# Altium schematic sheet Bitcoiner_EB_Project_V1I1_Schematic.SchDoc (continued)

## Library data of the parts
C1 C3 C12 C13 C33 = T491A475K016AT: Tantalum capacitor 4.7 uF 16 VDC, T491A475K016AT, KEMET; Capacitance: 4.7uF; Dissipation Factor: 6%; ESR (Equivalent Series Resistance): 4R; Leakage Current: 800nA; Life (Hours): 2000Hour; Polarity: Polar; Ripple Current: 137mA; Tolerance: 10%; Case Code (Imperial): 1206; Case Code (Metric): 3216; Case/Package: 1206; Category: Tantalum Capacitors; Component Kind: Standard; ComponentLink1Description: https://datasheet.ciiva.com/34302/1573425-34302513.pdf?src-supplier=Jameco; ComponentLink1URL: https://datasheet.ciiva.com/34302/1573425-34302513.pdf?src-supplier=Jameco; ComponentLink2Description: https://datasheet.ciiva.com/11793/0900766b80718482-11793043.pdf?src-supplier=RSComponents; ComponentLink2URL: https://datasheet.ciiva.com/11793/0900766b80718482-11793043.pdf?src-supplier=RSComponents; ComponentLink3Description: https://datasheet.ciiva.com/20171/458253-da-en-01-20171911.pdf?src-supplier=Rapid+Electronics; ComponentLink3URL: https://datasheet.ciiva.com/20171/458253-da-en-01-20171911.pdf?src-supplier=Rapid+Electronics; ComponentLink4Description: https://datasheet.ciiva.com/257/kem-t2005-t491-257536.pdf?src-supplier=Digi-Key; ComponentLink4URL: https://datasheet.ciiva.com/257/kem-t2005-t491-257536.pdf?src-supplier=Digi-Key; ComponentLink5Description: https://datasheet.ciiva.com/257/kem-t2005-t491-257537.pdf?src-supplier=Digi-Key; ComponentLink5URL: https://datasheet.ciiva.com/257/kem-t2005-t491-257537.pdf?src-supplier=Digi-Key; ComponentLink6Description: https://datasheet.ciiva.com/257/kem-t2005-t491-257538.pdf?src-supplier=Digi-Key; ComponentLink6URL: https://datasheet.ciiva.com/257/kem-t2005-t491-257538.pdf?src-supplier=Digi-Key; ComponentLink7Description: https://datasheet.ciiva.com/7696/1854511-7696309.pdf?src-supplier=Element14; ComponentLink7URL: https://datasheet.ciiva.com/7696/1854511-7696309.pdf?src-supplier=Element14; ComponentLink8Description: https://datasheet.ciiva.com/14605/70095774-14605189.pdf?src-supplier=Allied+Electronics; ComponentLink8URL: https://datasheet.ciiva.com/14605/70095774-14605189.pdf?src-supplier=Allied+Electronics; ComponentLink9Description: https://datasheet.ciiva.com/7696/1854511-7696310.pdf?src-supplier=Element14; ComponentLink9URL: https://datasheet.ciiva.com/7696/1854511-7696310.pdf?src-supplier=Element14; ComponentLink10Description: https://datasheet.ciiva.com/7696/1854511-7696311.pdf?src-supplier=Element14; ComponentLink10URL: https://datasheet.ciiva.com/7696/1854511-7696311.pdf?src-supplier=Element14; ComponentLink11Description: https://datasheet.ciiva.com/7696/1854511-7696312.pdf?src-supplier=Element14; ComponentLink11URL: https://datasheet.ciiva.com/7696/1854511-7696312.pdf?src-supplier=Element14; ComponentLink12Description: https://datasheet.ciiva.com/12883/kem-t2005-t491-12883130.pdf?src-supplier=Mouser; ComponentLink12URL: https://datasheet.ciiva.com/12883/kem-t2005-t491-12883130.pdf?src-supplier=Mouser; ComponentLink13Description: https://datasheet.ciiva.com/14603/70095774-14603739.pdf?src-supplier=Allied+Electronics; ComponentLink13URL: https://datasheet.ciiva.com/14603/70095774-14603739.pdf?src-supplier=Allied+Electronics; ComponentLink14Description: https://datasheet.ciiva.com/5934/kem-t2005-t491-5934799.pdf?src-supplier=Farnell; ComponentLink14URL: https://datasheet.ciiva.com/5934/kem-t2005-t491-5934799.pdf?src-supplier=Farnell; ComponentLink15Description: https://datasheet.ciiva.com/29244/458253-da-01-en-tantal-kondensator-t491a475k016at-29244572.pdf?src-supplier=Conrad; ComponentLink15URL: https://datasheet.ciiva.com/29244/458253-da-01-en-tantal-kondensator-t491a475k016at-29244572.pdf?src-supplier=Conrad; Construction: Flat; Depth: 1.6mm; Dielectric Material: Tantalum; Features: General Purpose; Height: 1.6mm; Height - Seated (Max): 0.071inch; Lead Free: Lead Free; Length: 3.2mm; Manufacturer: KEMET; Manufacturer Part Number: T491A475K016AT; Max Operating Temperature: 125°C; Min Operating Temperature: -55°C; Mount: Surface Mount,PCB; Number of Pins: 2; Package Quantity: 1; Packaging: Tape and Reel; Pricing 1: 1=0.43000, 10=0.24500, 50=0.24500, 100=0.14900, 1000=0.12200, 10000=0.10600 (USD); Radiation Hardening: No; REACH SVHC: No SVHC; RoHS: Yes; RoHS Compliant: Yes; Series: T491; Stock 1: 9766; Supplier 1: Mouser; Supplier Part Number 1: 80-T491A475K016; Termination: SMD/SMT; Voltage Rating: 16V; Voltage Rating (DC): 16V; Width: 1.6mm; Library Name: Bitcoiner_EB_Project_V1I1.SchLib
  C1 C3 C12 C13: Voltage: 16V
C2 C4-C6 C9-C11 C16 C18-C28 C30 = 08055C104KAT4A: AVX - 08055C104KAT4A - Multilayer Ceramic Capacitor, 0.1 F, 10%, X7R, 50 V, 0805 [2012 Metric] RoHS Compliant: Yes; Manufacturer: Kyocera AVX; Capacitance: 100nF; Dissipation Factor: 2.5%; Voltage: 50V; Case Code (Imperial): 0805; Case Code (Metric): 2012; Case/Package: 0805; Category: Ceramic Capacitors; Component Kind: Standard; ComponentLink1Description: https://datasheet.ciiva.com/2988/cx7r-2988016.pdf?src-supplier=Avnet; ComponentLink1URL: https://datasheet.ciiva.com/2988/cx7r-2988016.pdf?src-supplier=Avnet; ComponentLink2Description: https://datasheet.ciiva.com/28613/1679506-28613114.pdf?src-supplier=Element14; ComponentLink2URL: https://datasheet.ciiva.com/28613/1679506-28613114.pdf?src-supplier=Element14; ComponentLink3Description: https://datasheet.ciiva.com/5423/cx7r-218113-5423437.pdf?src-supplier=Mouser; ComponentLink3URL: https://datasheet.ciiva.com/5423/cx7r-218113-5423437.pdf?src-supplier=Mouser; ComponentLink4Description: https://datasheet.ciiva.com/16222/cx7r-16222253.pdf?src-supplier=Future+Electronics; ComponentLink4URL: https://datasheet.ciiva.com/16222/cx7r-16222253.pdf?src-supplier=Future+Electronics; ComponentLink5Description: https://datasheet.ciiva.com/22676/1679506-22676605.pdf?src-supplier=Element14; ComponentLink5URL: https://datasheet.ciiva.com/22676/1679506-22676605.pdf?src-supplier=Element14; ComponentLink6Description: https://datasheet.ciiva.com/2988/cx7r-2988015.pdf?src-supplier=Avnet; ComponentLink6URL: https://datasheet.ciiva.com/2988/cx7r-2988015.pdf?src-supplier=Avnet; Construction: Flat; Depth: 1.25mm; Dielectric: X7R; Dielectric Material: Ceramic; Height: 0.94mm; Lead Free: Lead Free; Length: 2.01mm; Manufacturer Part Number: 08055C104KAT4A; Max Operating Temperature: 125°C; Min Operating Temperature: -55°C; Mount: Surface Mount; Number of Pins: 2; Package Quantity: 15000; Packaging: Tape and Reel; Pricing 1: 1=0.10000, 10=0.04000, 50=0.04000, 100=0.03300, 1000=0.01900, 10000=0.01500 (USD); Radiation Hardening: No; REACH SVHC: No SVHC; RoHS: Yes; RoHS Compliant: Yes; Stock 1: 466031; Supplier 1: Mouser; Supplier Part Number 1: 581-08055C104KAT4A; Termination: SMD/SMT; Tolerance: 10%; Voltage Rating: 50V; Voltage Rating (DC): 50V; Width: 1.25mm; Library Name: Bitcoiner_EB_Project_V1I1.SchLib
C7 C8 = C0805C180J5GAC: Cap Ceramic 18pF 50V C0G 5% Pad SMD 0805 125°C Extreme Low ESR Bag; Manufacturer: KEMET; Capacitance: 18pF; Case Code (Imperial): 0805; Case Code (Metric): 2012; Case/Package: 0805; Category: Ceramic Capacitors; Component Kind: Standard; ComponentLink1Description: https://datasheet.ciiva.com/11651/kem-c1003-c0g-smd-11651038.pdf?src-supplier=Avnet; ComponentLink1URL: https://datasheet.ciiva.com/11651/kem-c1003-c0g-smd-11651038.pdf?src-supplier=Avnet; Construction: Flat; Depth: 1.25mm; Dielectric: C0G; Dielectric Material: Ceramic; Height: 0.78mm; Lead Free: Lead Free; Length: 2mm; Manufacturer Part Number: C0805C180J5GAC; Max Operating Temperature: 125°C; Min Operating Temperature: -55°C; Mount: Surface Mount; Number of Pins: 2; Package Quantity: 4000; Packaging: Tape and Reel; Pricing 1: 1=0.11000, 10=0.04800, 50=0.04800, 100=0.03400, 1000=0.02300, 10000=0.01600 (USD); Radiation Hardening: No; RoHS: Yes; RoHS Compliant: Yes; Series: C0805C; Stock 1: 13285; Supplier 1: Mouser; Supplier Part Number 1: 80-C0805C180J5GAC; Termination: SMD/SMT; Tolerance: 5%; Voltage Rating (DC): 50V; Width: 1.2mm; Library Name: Bitcoiner_EB_Project_V1I1.SchLib
C14 C15 = C0805C105K3RAC7210: Cap Ceramic 1uF 25V X7R 10% SMD 0805 125C Plastic T/R; Manufacturer: KEMET; Capacitance: 1uF; Case/Package: 0805; Category: Ceramic Capacitors; Component Kind: Standard; ComponentLink1Description: https://datasheet.ciiva.com/16623/datasheetpn-c0805c105k3rac7210-16623618.pdf?src-supplier=Avnet+Europe; ComponentLink1URL: https://datasheet.ciiva.com/16623/datasheetpn-c0805c105k3rac7210-16623618.pdf?src-supplier=Avnet+Europe; ComponentLink2Description: https://datasheet.ciiva.com/26847/getdatasheetpartid-385653-26847803.pdf?src-supplier=Verical; ComponentLink2URL: https://datasheet.ciiva.com/26847/getdatasheetpartid-385653-26847803.pdf?src-supplier=Verical; Construction: Flat; Depth: 1.25mm; Dielectric: X7R; Dielectric Material: Ceramic; Height: 1.25mm; Length: 2mm; Manufacturer Part Number: C0805C105K3RAC7210; Max Operating Temperature: 125°C; Min Operating Temperature: -55°C; Mount: Surface Mount; Packaging: Tape and Reel; Pricing 1: 1=0.14000, 10=0.05600, 50=0.05600, 100=0.04600, 1000=0.02700, 10000=0.02200 (USD); Radiation Hardening: No; RoHS: Yes; RoHS Compliant: Yes; Stock 1: 18487; Supplier 1: Mouser; Supplier Part Number 1: 80-C0805C105K3R7210; Tolerance: 10%; Library Name: Bitcoiner_EB_Project_V1I1.SchLib
C17 C29 = GRM21BR61E106KA73K: CAP CER 10UF 25V X5R 0805; Manufacturer: Murata; Tolerance: 10%; Capacitance: 10uF; Case/Package: 0805; Category: Ceramic Capacitors; Component Kind: Standard; ComponentLink1Description: http://www.mouser.com/ds/2/281/c02e-2905.pdf?src-supplier=Mouser; ComponentLink1URL: http://www.mouser.com/ds/2/281/c02e-2905.pdf?src-supplier=Mouser; ComponentLink2Description: http://search.murata.co.jp/Ceramy/image/img/A01X/G101/ENG/GRM21BR61E106KA73-01.pdf?src-supplier=Digi-Key; ComponentLink2URL: http://search.murata.co.jp/Ceramy/image/img/A01X/G101/ENG/GRM21BR61E106KA73-01.pdf?src-supplier=Digi-Key; ComponentLink3Description: https://search.murata.co.jp/Ceramy/image/img/A01X/G101/ENG/GRM21BR61E106KA73-01.pdf?src-supplier=Digi-Key; ComponentLink3URL: https://search.murata.co.jp/Ceramy/image/img/A01X/G101/ENG/GRM21BR61E106KA73-01.pdf?src-supplier=Digi-Key; Dielectric: X5R; Length: 0.079inch; Manufacturer Part Number: GRM21BR61E106KA73K; Max Operating Temperature: 85°C; Min Operating Temperature: -55°C; Mount: Surface Mount; Package Quantity: 10000; Packaging: Tape and Reel; Pricing 1: 1=0.23000, 10=0.09400, 50=0.09400, 100=0.08000, 1000=0.04900, 10000=0.03900 (USD); RoHS: Yes; RoHS Compliant: Yes; Stock 1: 5264; Supplier 1: Mouser; Supplier Part Number 1: 81-GRM21BR61E106KA3K; Thickness: 0.055inch; Voltage Rating (DC): 25V; Weight: 0.000335oz; Width: 0.049inch; Library Name: Bitcoiner_EB_Project_V1I1.SchLib
  C17: Voltage Rating: 25V
C31 C32 = CL21A226MPCLRNC: Cap Ceramic 22uF 10V X5R 20% SMD 0805 85C Cardboard T/R; Manufacturer: Samsung; Capacitance: 22uF; Case Code (Imperial): 0805; Case Code (Metric): 2012; Case/Package: 0805; Category: Ceramic Capacitors; Component Kind: Standard; ComponentLink1Description: https://datasheet.ciiva.com/15195/getdatasheetpartid-353187-15195862.pdf?src-supplier=Verical; ComponentLink1URL: https://datasheet.ciiva.com/15195/getdatasheetpartid-353187-15195862.pdf?src-supplier=Verical; ComponentLink2Description: https://datasheet.ciiva.com/25711/cl21a226mpclrnc-25711362.pdf?src-supplier=Digi-Key; ComponentLink2URL: https://datasheet.ciiva.com/25711/cl21a226mpclrnc-25711362.pdf?src-supplier=Digi-Key; ComponentLink3Description: https://datasheet.ciiva.com/29199/454635-da-01-en-vs-kondensator-0805-29199617.pdf?src-supplier=Conrad; ComponentLink3URL: https://datasheet.ciiva.com/29199/454635-da-01-en-vs-kondensator-0805-29199617.pdf?src-supplier=Conrad; ComponentLink4Description: https://datasheet.ciiva.com/16428/cl05b104kq5nnnc-16428876.pdf?src-supplier=Future+Electronics; ComponentLink4URL: https://datasheet.ciiva.com/16428/cl05b104kq5nnnc-16428876.pdf?src-supplier=Future+Electronics; Contact Plating: Tin; Depth: 1.25mm; Dielectric: X5R; Height: 0.85mm; Length: 2mm; Manufacturer Part Number: CL21A226MPCLRNC; Material: Ceramic; Max Operating Temperature: 85°C; Min Operating Temperature: -55°C; Mount: Surface Mount; Packaging: Tape and Reel; Pricing 1: 1=0.26000, 10=0.11700, 50=0.11700, 100=0.10000, 1000=0.06200, 10000=0.05400 (USD); Radiation Hardening: No; RoHS: Yes; Stock 1: 45867; Supplier 1: Mouser; Supplier Part Number 1: 187-CL21A226MPCLRNC; Thickness: 0.037inch; Tolerance: 20%; Width: 0.049inch; Library Name: Bitcoiner_EB_Project_V1I1.SchLib
  C31: Voltage Rating: 10V
D1 = BAS16GWJ: High-speed switching diode BAS16GW/SOD2/REEL 13 Q1/T1 S"; Manufacturer: Nexperia; Category: Rectifier Diodes; Component Kind: Standard; ComponentLink1Description: https://assets.nexperia.com/documents/data-sheet/BAS16GW.pdf?src-supplier=Digi-Key; ComponentLink1URL: https://assets.nexperia.com/documents/data-sheet/BAS16GW.pdf?src-supplier=Digi-Key; Manufacturer Part Number: BAS16GWJ; Pricing 1: 1=0.11000, 10=0.07700, 50=0.07700, 100=0.04100, 1000=0.01900, 10000=0.01200 (USD); RoHS: Yes; Stock 1: 2099; Supplier 1: Mouser; Supplier Part Number 1: 841-BAS16GWJ; Library Name: Bitcoiner_EB_Project_V1I1.SchLib
DS1 = LDD-E2802RD: Dual Digit 565 nm Green 0.28 inch Common Anode Seven Segment Display; Manufacturer: Lumex; Forward Current: 10mA; Forward Voltage: 2.2V; Number of LEDs: 16; Power Consumption: 105mW; Viewing Area (height): 7mm; Wavelength: 565nm; Category: Uncategorized; Color: Green; Component Kind: Standard; ComponentLink1Description: https://datasheet.ciiva.com/12093/ldd-e2802rd-106744-12093301.pdf?src-supplier=Mouser; ComponentLink1URL: https://datasheet.ciiva.com/12093/ldd-e2802rd-106744-12093301.pdf?src-supplier=Mouser; Display Type: Segment; Element Configuration: Common Anode; Illumination Color: Green; Lead Free: Lead Free; Manufacturer Part Number: LDD-E2802RD; Max Operating Temperature: 85°C; Min Operating Temperature: -30°C; Mount: Through Hole; Number of Pins: 10; Packaging: Rail/Tube; Pricing 1: 1=1.27000, 10=0.80000, 50=0.80000, 100=0.64000, 1000=0.44700, 10000=0.40000 (USD); RoHS: Yes; RoHS Compliant: No; Stock 1: 124; Supplier 1: Mouser; Library Name: Bitcoiner_EB_Project_V1I1.SchLib
FB1-FB3 = MMZ2012R601AT000: TDK         MMZ2012R601AT000            FERRITE BEAD, 0.2OHM, 500MA, 0805; DC Resistance (DCR): 200mR; Tolerance: 25%; Case Code (Imperial): 0805; Case Code (Metric): 2012; Case/Package: 0805; Category: Inductors; Component Kind: Standard; ComponentLink1Description: https://datasheet.ciiva.com/8077/55163-8077040.pdf?src-supplier=Element14; ComponentLink1URL: https://datasheet.ciiva.com/8077/55163-8077040.pdf?src-supplier=Element14; ComponentLink2Description: https://datasheet.ciiva.com/46634/beads-commercial-signal-mmz-en-46634123.pdf?src-supplier=Digi-Key; ComponentLink2URL: https://datasheet.ciiva.com/46634/beads-commercial-signal-mmz-en-46634123.pdf?src-supplier=Digi-Key; ComponentLink3Description: https://datasheet.ciiva.com/46634/beads-commercial-signal-mmz-en-46634155.pdf?src-supplier=Digi-Key; ComponentLink3URL: https://datasheet.ciiva.com/46634/beads-commercial-signal-mmz-en-46634155.pdf?src-supplier=Digi-Key; ComponentLink4Description: https://datasheet.ciiva.com/46381/712877-46381661.pdf?src-supplier=Element14; ComponentLink4URL: https://datasheet.ciiva.com/46381/712877-46381661.pdf?src-supplier=Element14; ComponentLink5Description: https://datasheet.ciiva.com/46634/beads-commercial-signal-mmz-en-46634125.pdf?src-supplier=Digi-Key; ComponentLink5URL: https://datasheet.ciiva.com/46634/beads-commercial-signal-mmz-en-46634125.pdf?src-supplier=Digi-Key; ComponentLink6Description: https://datasheet.ciiva.com/8077/55163-8077039.pdf?src-supplier=Element14; ComponentLink6URL: https://datasheet.ciiva.com/8077/55163-8077039.pdf?src-supplier=Element14; Core Material: Ferrite; Current Rating: 500mA; Depth: 1.25mm; Height: 0.041inch; Length: 0.079inch; Manufacturer: TDK; Manufacturer Part Number: MMZ2012R601AT000; Max DC Current: 500mA; Mount: Surface Mount; Number of Pins: 2; Package Quantity: 1; Packaging: Cut Tape; Pricing 1: 1=0.10000, 10=0.05100, 50=0.05100, 100=0.03600, 1000=0.02600, 10000=0.02000 (USD); REACH SVHC: No SVHC; RoHS: Yes; RoHS Compliant: Yes; Series: MMZ; Stock 1: 23788; Supplier 1: Mouser; Supplier Part Number 1: 810-MMZ2012R601AT000; Width: 0.049inch; Library Name: Bitcoiner_EB_Project_V1I1.SchLib
J1 = G800W302018EU: Manufacturer: Amphenol; Component Kind: Standard; Manufacturer Part Number: G800W302018EU; Pricing 1: 1=0.15000, 10=0.07700, 50=0.07700, 100=0.07400, 1000=0.06500, 10000=0.05300 (USD); RoHS: Yes; RoHS Compliant: Yes; Stock 1: 12771; Supplier 1: Mouser; Supplier Part Number 1: 523-G800W302018EU; Library Name: Bitcoiner_EB_Project_V1I1.SchLib
J2 J5 = SSW-106-02-T-D-RA: Connector; Manufacturer_Name: SAMTEC; Component Kind: Standard; Datasheet Link: https://componentsearchengine.com//SSW-106-02-T-D-RA.pdf; Manufacturer_Part_Number: SSW-106-02-T-D-RA; Mouser2 Part Number: 200-SSW10602TDRA; Mouser2 Price/Stock: https://www.mouser.com/Search/Refine.aspx?Keyword=200-SSW10602TDRA; Library Name: Bitcoiner_EB_Project_V1I1.SchLib
J4 = 10104111-0001LF: Conn USB Type A/B RCP 5 POS 0.65mm Solder RA SMD 5 Terminal 1 Port T/R; Manufacturer: AMPHENOL ICC (FCI); Current Rating: 1.8A; Gender: Receptacle; Mating Cycles: 10000; Number of Contacts: 5; Number of Ports: 1; Shielding: Shielded; Component Kind: Standard; ComponentLink1Description: http://www.farnell.com/datasheets/2366786.pdf?src-supplier=Farnell; ComponentLink1URL: http://www.farnell.com/datasheets/2366786.pdf?src-supplier=Farnell; ComponentLink2Description: https://cdn.amphenol-icc.com/media/wysiwyg/files/drawing/10104111.pdf?src-supplier=Digi-Key; ComponentLink2URL: https://cdn.amphenol-icc.com/media/wysiwyg/files/drawing/10104111.pdf?src-supplier=Digi-Key; ComponentLink3Description: http://www.farnell.com/datasheets/2366786.pdf?src-supplier=Newark; ComponentLink3URL: http://www.farnell.com/datasheets/2366786.pdf?src-supplier=Newark; ComponentLink4Description: http://www.farnell.com/datasheets/2366786.pdf?src-supplier=Element14; ComponentLink4URL: http://www.farnell.com/datasheets/2366786.pdf?src-supplier=Element14; Contact Plating: Gold; Flammability Rating: UL94 V-0; Insulation Color: Grey; Manufacturer Part Number: 10104111-0001LF; Max Operating Temperature: 80°C; Min Operating Temperature: -30°C; Mount: Surface Mount; Packaging: Cut Tape; Pricing 1: 1=0.78000, 10=0.61900, 50=0.61900, 100=0.53800, 1000=0.43000, 10000=0.38500 (USD); RoHS: No; Stock 1: 372; Supplier 1: Mouser; Supplier Part Number 1: 649-10104111-0001LF; Termination: Solder; Library Name: Bitcoiner_EB_Project_V1I1.SchLib
J7 = 10129378-905001BLF: Manufacturer: Amphenol ICC; Component Kind: Standard; Description: HDR FEMALE 1x5 2.54MM; Manufacturer Part Number: 10129378-905001BLF; Pricing 1: 1=0.18000, 10=0.08900, 50=0.08900, 100=0.08500, 1000=0.07400, 10000=0.06200 (USD); RoHS: No; Stock 1: 4420; Supplier 1: Mouser; Supplier Part Number 1: 649-1012937890501BLF; Library Name: Bitcoiner_EB_Project_V1I1.SchLib
J9 = RAHHD19TR: HDMI Connector; Manufacturer: Switchcraft Conxall; Manufacturer_Name: Switchcraft; Component Kind: Standard; Datasheet Link: https://www.switchcraft.com/Drawings/RAHHD19TR_CD.pdf; Height: 6.68mm; Manufacturer Part Number: RAHHD19TR; Manufacturer_Part_Number: RAHHD19TR; Pricing 1: 1=0.77000, 10=0.62400, 50=0.62400, 100=0.59200, 1000=0.49200, 10000=0.46800 (USD); RoHS: No; Stock 1: 605; Supplier 1: Mouser; Supplier Part Number 1: 502-RAHHD19TR; Library Name: Bitcoiner_EB_Project_V1I1.SchLib
J10 = KLDX-0202-BC: Conn Power PIN 2 POS Solder RA Thru-Hole 3 Terminal 1 Port; Manufacturer: Kycon; Current Rating: 3.5A; Gender: Female; Insulation Resistance: 100MR; Number of Contacts: 2; Voltage Rating: 24V; Category: Connectors; Color: Black; Component Kind: Standard; ComponentLink1Description: https://datasheet.ciiva.com/4561/kldx-0202-ac20-20bc-182163-4561492.pdf?src-supplier=Mouser; ComponentLink1URL: https://datasheet.ciiva.com/4561/kldx-0202-ac20-20bc-182163-4561492.pdf?src-supplier=Mouser; Connector Type: Power; Contact Gender: Female; Contact Material: Brass; Contact Plating: Tin; Flammability Rating: UL94 V-0; Housing Material: PBT; Manufacturer Part Number: KLDX-0202-BC; Max Operating Temperature: 85°C; Min Operating Temperature: -25°C; Mount: Through Hole; Orientation: Right Angle; Package Quantity: 500; Packaging: Bag; Pricing 1: 1=0.53000, 10=0.40100, 50=0.40100, 100=0.35100, 1000=0.27600, 10000=0.22200 (USD); Radiation Hardening: No; RoHS: Yes; RoHS Compliant: Yes; Series: KLDX; Stock 1: 4244; Supplier 1: Mouser; Supplier Part Number 1: 806-KLDX-0202-BC; Termination: Solder; Voltage Rating (DC): 24V; Library Name: Bitcoiner_EB_Project_V1I1.SchLib
J11 = G800W305018EU: HDR MALE 1x3 2.54MM; Manufacturer: Amphenol; Component Kind: Standard; Manufacturer Part Number: G800W305018EU; Pricing 1: 1=0.10000, 10=0.04000, 50=0.04000, 100=0.03800, 1000=0.03200, 10000=0.02700 (USD); RoHS: Yes; Stock 1: 5972; Supplier 1: Mouser; Supplier Part Number 1: 523-G800W305018EU; Library Name: Bitcoiner_EB_Project_V1I1.SchLib
L1 = TYS60454R7M-10: Pwrind, SMT, Shld, Lrated / 6.0X6.0X4.5MM / Uom=ea / Wire Wound Inductive / Fixed Inductor; Manufacturer: Laird Steward; DC Resistance (DCR): 26mR; Inductance: 4.7uH; Saturation Current: 4.97A; Self Resonant Frequency: 24MHz; Shielding: Shielded; Test Frequency: 100kHz; Tolerance: 20%; Case/Package: Nonstandard; Category: Fixed Inductors; Component Kind: Standard; ComponentLink1Description: http://assets.lairdtech.com/home/brandworld/files/TYS60454R7M-10.pdf?src-supplier=Digi-Key; ComponentLink1URL: http://assets.lairdtech.com/home/brandworld/files/TYS60454R7M-10.pdf?src-supplier=Digi-Key; ComponentLink2Description: http://www.lairdtech.com/WorkArea/linkit.aspx?LinkIdentifier=id&ItemID=2147484897&src-supplier=Avnet; ComponentLink2URL: http://www.lairdtech.com/WorkArea/linkit.aspx?LinkIdentifier=id&ItemID=2147484897&src-supplier=Avnet; Height - Seated (Max): 0.185inch; Length: 0.236inch; Manufacturer Part Number: TYS60454R7M-10; Max DC Current: 3.3A; Max Operating Temperature: 125°C; Min Operating Temperature: -40°C; Mount: Surface Mount; Packaging: Tape and Reel; Pricing 1: 1=0.24000, 10=0.22900, 50=0.21200, 100=0.21000, 1000=0.16600, 10000=0.16100 (USD); Ratings: AEC-Q200; Resistor Type: Wirewound; RoHS: Yes; RoHS Compliant: Yes; Stock 1: 5979; Supplier 1: Mouser; Supplier Part Number 1: 875-TYS60454R7M-10; Width: 0.236inch; Library Name: Bitcoiner_EB_Project_V1I1.SchLib
LED1-LED3 = IN-S63AT5A: LED Uni-Color Amber 609nm Automotive 2-Pin Chip 0603(1608Metric) T/R; Manufacturer: Inolux; Color: Orange; Dominant Wavelength: 605nm; Forward Voltage: 1.9V; Lens Color: Clear; Lens Size: 0.8mm; Lens Style: Flat; Luminous Intensity: 45mcd; Test Current: 5mA; Viewing Angle: 120°; Case Code (Imperial): 0603; Case Code (Metric): 1608; Case/Package: 0603; Category: LEDs; Component Kind: Standard; ComponentLink1Description: http://www.inolux-corp.com/datasheet/SMDLED/Mono%20Color%20Top%20View/IN-S63AT%20Series_V1.1.pdf?src-supplier=Digi-Key; ComponentLink1URL: http://www.inolux-corp.com/datasheet/SMDLED/Mono%20Color%20Top%20View/IN-S63AT%20Series_V1.1.pdf?src-supplier=Digi-Key; Height: 0.4mm; Length: 1.6mm; Lens Transparency: Clear; Manufacturer Part Number: IN-S63AT5A; Mount: Surface Mount; Packaging: Tape and Reel; Pricing 1: 1=0.34000, 10=0.18900, 50=0.18900, 100=0.07600, 1000=0.06300, 10000=0.04000 (USD); RoHS: No; Stock 1: 8000; Supplier 1: Mouser; Supplier Part Number 1: 743-IN-S63AT5A; Width: 0.8mm; Library Name: Bitcoiner_EB_Project_V1I1.SchLib
LINK? = 382811-8: CONN SHUNT 2POS OPEN 2.54MM; Manufacturer: TE Connectivity; Approvals: UL,CSA; Contact Current Rating: 3A; Insulation Resistance: 1GR; Number of Contacts: 2; Plating Thickness: 0.127um; Category: Board to Board Connectors; Color: Black; ComponentLink1Description: https://datasheet.ciiva.com/25616/0900766b810caf26-25616808.pdf?src-supplier=RSComponents; ComponentLink1URL: https://datasheet.ciiva.com/25616/0900766b810caf26-25616808.pdf?src-supplier=RSComponents; ComponentLink2Description: https://datasheet.ciiva.com/767/eng-cd-382811-l-767846.pdf?src-supplier=Digi-Key; ComponentLink2URL: https://datasheet.ciiva.com/767/eng-cd-382811-l-767846.pdf?src-supplier=Digi-Key; ComponentLink3Description: https://datasheet.ciiva.com/16547/eng-cs-1307819-section-6-7and8-0808-16547046.pdf?src-supplier=Avnet+Europe; ComponentLink3URL: https://datasheet.ciiva.com/16547/eng-cs-1307819-section-6-7and8-0808-16547046.pdf?src-supplier=Avnet+Europe; ComponentLink4Description: https://datasheet.ciiva.com/1412/eng-cs-1307819-section-6-7and8-0808-1412631.pdf?src-supplier=Element14; ComponentLink4URL: https://datasheet.ciiva.com/1412/eng-cs-1307819-section-6-7and8-0808-1412631.pdf?src-supplier=Element14; ComponentLink5Description: https://datasheet.ciiva.com/14640/70083050-14640423.pdf?src-supplier=Allied+Electronics; ComponentLink5URL: https://datasheet.ciiva.com/14640/70083050-14640423.pdf?src-supplier=Allied+Electronics; ComponentLink6Description: https://datasheet.ciiva.com/16469/eng-cs-1307819-section-6-7and8-0808-16469032.pdf?src-supplier=Avnet+Asia; ComponentLink6URL: https://datasheet.ciiva.com/16469/eng-cs-1307819-section-6-7and8-0808-16469032.pdf?src-supplier=Avnet+Asia; ComponentLink7Description: https://datasheet.ciiva.com/3120/eng-cs-1307819-section-6-7and8-0808-3120187.pdf?src-supplier=Avnet; ComponentLink7URL: https://datasheet.ciiva.com/3120/eng-cs-1307819-section-6-7and8-0808-3120187.pdf?src-supplier=Avnet; ComponentLink8Description: https://datasheet.ciiva.com/30253/eng-cs-1307819-section-6-7and8-0808-30253247.pdf?src-supplier=Onlinecomponents.com; ComponentLink8URL: https://datasheet.ciiva.com/30253/eng-cs-1307819-section-6-7and8-0808-30253247.pdf?src-supplier=Onlinecomponents.com; Contact Gender: Female; Contact Material: Bronze; Contact Plating: Gold; Current Rating: 3A; Depth: 2.54mm; ELV: Compliant; Flammability Rating: UL94 V-0; Height: 6.35mm; Housing Color: Black; Housing Material: Polyester; Lead Free: Lead Free; Length: 5.08mm; Manufacturer Part Number: 382811-8; Max Operating Temperature: 105°C; Min Operating Temperature: -65°C; Number of Positions: 2; Number of Rows: 1; Orientation: Straight; Package Quantity: 1500; Packaging: Box; Pitch: 2.54mm; Pricing 1: 1=0.20000, 10=0.16400, 50=0.16400, 100=0.12500, 500=0.11300, 1000=0.09200, 2500=0.08400, 5000=0.08100, 10000=0.07300, 25000=0.06700 (USD); Radiation Hardening: No; REACH SVHC: Unknown; RoHS: Yes; Stock 1: 1961; Supplier 1: Mouser; Supplier Part Number 1: 571-3828118; Library Name: Bitcoiner_EB_Project_V1I1.SchLib
MH1-MH4 = MH_7.4MMNC_3.2MMD: Mounting Hole 7.4mm Diameter (Non Copper), 3.2 Drill NPTH; Library Name: Bitcoiner_EB_Project_V1I1.SchLib
Q1 = BC857BS,115: NEXPERIA - BC857BS,115 - TRANSISTOR, DUAL, PNP, SC-88; Category: BJTs; Component Kind: Standard; ComponentLink1Description: http://www.farnell.com/datasheets/708560.pdf?src-supplier=Farnell; ComponentLink1URL: http://www.farnell.com/datasheets/708560.pdf?src-supplier=Farnell; ComponentLink2Description: https://assets.nexperia.com/documents/data-sheet/BC857BS.pdf?src-supplier=Digi-Key; ComponentLink2URL: https://assets.nexperia.com/documents/data-sheet/BC857BS.pdf?src-supplier=Digi-Key; Manufacturer: Nexperia; Manufacturer Part Number: BC857BS,115; Pricing 1: 1=0.19000, 10=0.12200, 50=0.12200, 100=0.06800, 1000=0.03800, 10000=0.02500 (USD); RoHS: Yes; RoHS Compliant: Yes; Stock 1: 20332; Supplier 1: Mouser; Supplier Part Number 1: 771-BC857BS-T/R; Library Name: Bitcoiner_EB_Project_V1I1.SchLib
R1 = RC0805FR-0712KL: Res Thick Film 0805 12K Ohm 1% 0.125W(1/8W) ±100ppm/C Molded SMD Paper T/R; Manufacturer: Yageo; Max Power Dissipation: 125mW; Resistance: 12kR; Temperature Coefficient: 100ppm/°C; Voltage Rating: 150V; Case Code (Imperial): 0805; Case Code (Metric): 2012; Case/Package: 0805; Category: Chip SMD Resistors; Component Kind: Standard; ComponentLink1Description: https://datasheet.ciiva.com/12577/rc0805-12577253.pdf?src-supplier=Mouser; ComponentLink1URL: https://datasheet.ciiva.com/12577/rc0805-12577253.pdf?src-supplier=Mouser; ComponentLink2Description: https://datasheet.ciiva.com/2519/pyu-r-int-thick-7-2519831.pdf?src-supplier=Digi-Key; ComponentLink2URL: https://datasheet.ciiva.com/2519/pyu-r-int-thick-7-2519831.pdf?src-supplier=Digi-Key; ComponentLink3Description: https://datasheet.ciiva.com/2519/pyu-r-int-thick-7-2519832.pdf?src-supplier=Digi-Key; ComponentLink3URL: https://datasheet.ciiva.com/2519/pyu-r-int-thick-7-2519832.pdf?src-supplier=Digi-Key; ComponentLink4Description: https://datasheet.ciiva.com/2519/pyu-r-int-thick-7-2519833.pdf?src-supplier=Digi-Key; ComponentLink4URL: https://datasheet.ciiva.com/2519/pyu-r-int-thick-7-2519833.pdf?src-supplier=Digi-Key; ComponentLink5Description: https://datasheet.ciiva.com/17018/rc0805-17018634.pdf?src-supplier=Future+Electronics; ComponentLink5URL: https://datasheet.ciiva.com/17018/rc0805-17018634.pdf?src-supplier=Future+Electronics; ComponentLink6Description: https://datasheet.ciiva.com/7941/444164-7941693.pdf?src-supplier=Element14; ComponentLink6URL: https://datasheet.ciiva.com/7941/444164-7941693.pdf?src-supplier=Element14; ComponentLink7Description: https://datasheet.ciiva.com/7941/444164-7941694.pdf?src-supplier=Element14; ComponentLink7URL: https://datasheet.ciiva.com/7941/444164-7941694.pdf?src-supplier=Element14; Construction: Rectangular; Depth: 1.25mm; Features: Moisture Resistant; Height: 0.024inch; Lead Free: Lead Free; Length: 2mm; Manufacturer Part Number: RC0805FR-0712KL; Max Operating Temperature: 155°C; Military Standard: Not; Min Operating Temperature: -55°C; Number of Terminations: 2; Package Quantity: 1; Packaging: Tape and Reel; Power Rating: 125mW; Pricing 1: 1=0.13000, 10=0.03100, 50=0.03100, 100=0.01100, 1000=0.00800, 10000=0.00500 (USD); REACH SVHC: Unknown; Resistance Tolerance: 1%; Resistor Type: Thick Film; RoHS: Yes; RoHS Compliant: Yes; Series: RC; Size Code: 0805; Stock 1: 94553; Supplier 1: Mouser; Supplier Part Number 1: 603-RC0805FR-0712KL; Termination: SMD/SMT; Tolerance: 1%; Weight: 0.000194oz; Width: 1.25mm; Library Name: Bitcoiner_EB_Project_V1I1.SchLib
R2-R6 R10-R13 R36 R43 = RC0805FR-0710KL: RES SMD 10K OHM 1% 1/8W 0805; Manufacturer: Yageo; Max Power Dissipation: 125mW; Resistance: 10kR; Temperature Coefficient: 100ppm/°C; Voltage Rating: 150V; Case Code (Imperial): 0805; Case Code (Metric): 2012; Case/Package: 0805; Category: Chip SMD Resistors; Component Kind: Standard; Construction: Rectangular; Depth: 1.25mm; Features: Moisture Resistant; Height: 0.024inch; Lead Free: Lead Free; Length: 2mm; Manufacturer Part Number: RC0805FR-0710KL; Max Operating Temperature: 155°C; Military Standard: Not; Min Operating Temperature: -55°C; Number of Terminations: 2; Package Quantity: 1; Packaging: Tape and Reel; Power Rating: 125mW; Pricing 1: 1=0.10000, 10=0.03100, 50=0.03100, 100=0.01200, 1000=0.00700, 10000=0.00400 (USD); REACH SVHC: Unknown; Resistance Tolerance: 1%; Resistor Type: Thick Film; RoHS: Yes; RoHS Compliant: Yes; Series: RC; Size Code: 0805; Stock 1: 2657423; Supplier 1: Mouser; Supplier Part Number 1: 603-RC0805FR-0710KL; Technology: THICK FILM; Termination: SMD/SMT; Tolerance: 1%; Width: 0.049inch; Library Name: Bitcoiner_EB_Project_V1I1.SchLib
R7 R8 R41 = RC0805FR-07470RL: Res Thick Film 0805 470 Ohm 1% 1/8W +/-100ppm/ßC Molded SMD Paper T/R; Manufacturer: Yageo; Max Power Dissipation: 125mW; Temperature Coefficient: 100ppm/°C; Voltage Rating: 150V; Case Code (Imperial): 0805; Case Code (Metric): 2012; Case/Package: 0805; Category: Chip SMD Resistors; Component Kind: Standard; ComponentLink1Description: https://datasheet.ciiva.com/2457/pyu-r-int-thick-7-2457056.pdf?src-supplier=Digi-Key; ComponentLink1URL: https://datasheet.ciiva.com/2457/pyu-r-int-thick-7-2457056.pdf?src-supplier=Digi-Key; ComponentLink2Description: https://datasheet.ciiva.com/2457/pyu-r-int-thick-7-2457057.pdf?src-supplier=Digi-Key; ComponentLink2URL: https://datasheet.ciiva.com/2457/pyu-r-int-thick-7-2457057.pdf?src-supplier=Digi-Key; ComponentLink3Description: https://datasheet.ciiva.com/2457/pyu-r-int-thick-7-2457058.pdf?src-supplier=Digi-Key; ComponentLink3URL: https://datasheet.ciiva.com/2457/pyu-r-int-thick-7-2457058.pdf?src-supplier=Digi-Key; ComponentLink4Description: https://datasheet.ciiva.com/7941/444164-7941797.pdf?src-supplier=Element14; ComponentLink4URL: https://datasheet.ciiva.com/7941/444164-7941797.pdf?src-supplier=Element14; ComponentLink5Description: https://datasheet.ciiva.com/7941/444164-7941798.pdf?src-supplier=Element14; ComponentLink5URL: https://datasheet.ciiva.com/7941/444164-7941798.pdf?src-supplier=Element14; ComponentLink6Description: https://datasheet.ciiva.com/46447/444164-46447580.pdf?src-supplier=Element14; ComponentLink6URL: https://datasheet.ciiva.com/46447/444164-46447580.pdf?src-supplier=Element14; ComponentLink7Description: https://datasheet.ciiva.com/16745/rc0805-16745229.pdf?src-supplier=Future+Electronics; ComponentLink7URL: https://datasheet.ciiva.com/16745/rc0805-16745229.pdf?src-supplier=Future+Electronics; Construction: Rectangular; Depth: 1.25mm; Features: Moisture Resistant; Height: 0.5mm; Lead Free: Lead Free; Lead Pitch: 0.4mm; Length: 2mm; Manufacturer Part Number: RC0805FR-07470RL; Max Operating Temperature: 155°C; Military Standard: Not; Min Operating Temperature: -55°C; Number of Pins: 2; Number of Terminations: 2; Package Quantity: 5000; Packaging: Tape and Reel; Power Rating: 125mW; Pricing 1: 1=0.13000, 10=0.03100, 50=0.03100, 100=0.01100, 1000=0.00800, 10000=0.00500 (USD); REACH SVHC: Unknown; Resistance: 470R; Resistance Tolerance: 1%; Resistor Type: Thick Film; RoHS: Yes; RoHS Compliant: Yes; Series: RC; Size Code: 0805; Stock 1: 63482; Supplier 1: Mouser; Supplier Part Number 1: 603-RC0805FR-07470RL; Termination: SMD/SMT; Tolerance: 1%; Voltage Rating (DC): 150V; Width: 1.25mm; Library Name: Bitcoiner_EB_Project_V1I1.SchLib
R9 = RC0805FR-102KL: Res Thick Film 0805 2K Ohm 1% 1/8W 100ppm/C Molded SMD SMD Paper T/R; Manufacturer: Yageo; Resistance: 2kR; Temperature Coefficient: 100ppm/°C; Case/Package: 0805; Category: Chip SMD Resistors; Component Kind: Standard; ComponentLink1Description: http://components.arrow.com/part/dataview/0323_06931434?src-supplier=Arrow+Europe; ComponentLink1URL: http://components.arrow.com/part/dataview/0323_06931434?src-supplier=Arrow+Europe; ComponentLink2Description: http://www.yageo.com/documents/recent/PYu-RC_Group_51_RoHS_L_2.pdf?src-supplier=Avnet; ComponentLink2URL: http://www.yageo.com/documents/recent/PYu-RC_Group_51_RoHS_L_2.pdf?src-supplier=Avnet; ComponentLink3Description: http://www.yageo.com/documents/recent/PYu-RC0805_51_RoHS_L_5.pdf?src-supplier=Avnet+Europe; ComponentLink3URL: http://www.yageo.com/documents/recent/PYu-RC0805_51_RoHS_L_5.pdf?src-supplier=Avnet+Europe; Construction: Rectangular; Contact Plating: Tin,Matte; Depth: 1.25mm; Length: 2mm; Manufacturer Part Number: RC0805FR-102KL; Max Operating Temperature: 155°C; Military Standard: Not; Min Operating Temperature: -55°C; Packaging: Tape and Reel; Pricing 1: 1=0.13000, 10=0.03100, 50=0.03100, 100=0.01100, 1000=0.00800, 10000=0.00500 (USD); Radiation Hardening: No; Resistor Type: Thick Film; RoHS: Yes; RoHS Compliant: Yes; Stock 1: 19844; Supplier 1: Mouser; Supplier Part Number 1: 603-RC0805FR-102KL; Tolerance: 1%; Library Name: Bitcoiner_EB_Project_V1I1.SchLib
R14 R20 R22 R31-R35 R47-R62 = RC0805FR-07200RL: Res Thick Film 2.0 x 1.2 mm 200 Ohm 1% 0.125W(1/8W) 100ppm/ C Molded SMD Paper T/R; Manufacturer: Yageo; Max Power Dissipation: 125mW; Temperature Coefficient: 100ppm/°C; Voltage Rating: 150V; Case Code (Imperial): 0805; Case Code (Metric): 2012; Case/Package: 0805; Category: Chip SMD Resistors; Component Kind: Standard; ComponentLink1Description: https://datasheet.ciiva.com/15189/getdatasheetpartid-327982-15189926.pdf?src-supplier=Verical; ComponentLink1URL: https://datasheet.ciiva.com/15189/getdatasheetpartid-327982-15189926.pdf?src-supplier=Verical; ComponentLink2Description: https://datasheet.ciiva.com/2519/pyu-r-int-thick-7-2519720.pdf?src-supplier=Digi-Key; ComponentLink2URL: https://datasheet.ciiva.com/2519/pyu-r-int-thick-7-2519720.pdf?src-supplier=Digi-Key; ComponentLink3Description: https://datasheet.ciiva.com/2519/pyu-r-int-thick-7-2519721.pdf?src-supplier=Digi-Key; ComponentLink3URL: https://datasheet.ciiva.com/2519/pyu-r-int-thick-7-2519721.pdf?src-supplier=Digi-Key; ComponentLink4Description: https://datasheet.ciiva.com/2519/pyu-r-int-thick-7-2519722.pdf?src-supplier=Digi-Key; ComponentLink4URL: https://datasheet.ciiva.com/2519/pyu-r-int-thick-7-2519722.pdf?src-supplier=Digi-Key; ComponentLink5Description: https://datasheet.ciiva.com/12667/rc0805-12667066.pdf?src-supplier=Mouser; ComponentLink5URL: https://datasheet.ciiva.com/12667/rc0805-12667066.pdf?src-supplier=Mouser; Construction: Rectangular; Depth: 1.25mm; Features: Moisture Resistant; Height: 0.024inch; Lead Free: Lead Free; Length: 2mm; Manufacturer Part Number: RC0805FR-07200RL; Max Operating Temperature: 155°C; Military Standard: Not; Min Operating Temperature: -55°C; Mount: Surface Mount; Number of Terminations: 2; Package Quantity: 1; Packaging: Tape and Reel; Power Rating: 125mW; Pricing 1: 1=0.10000, 10=0.03500, 50=0.03500, 100=0.01200, 1000=0.00700, 10000=0.00400 (USD); Resistance: 200R; Resistor Type: Thick Film; RoHS: Yes; RoHS Compliant: Yes; Series: RC; Size Code: 0805; Stock 1: 137491; Supplier 1: Mouser; Supplier Part Number 1: 603-RC0805FR-07200RL; Termination: SMD/SMT; Tolerance: 1%; Voltage Rating (DC): 150V; Width: 0.049inch; Library Name: Bitcoiner_EB_Project_V1I1.SchLib
R15 R16 R18 R19 = RC0805FR-071K5L: Res Thick Film 0805 1.5K Ohm 1% 0.125W(1/8W) ±100ppm/C Molded SMD Paper T/R; Manufacturer: Yageo; Max Power Dissipation: 125mW; Resistance: 1.5kR; Temperature Coefficient: 100ppm/°C; Voltage Rating: 150V; Case Code (Imperial): 0805; Case Code (Metric): 2012; Case/Package: 0805; Category: Chip SMD Resistors; Component Kind: Standard; ComponentLink1Description: https://datasheet.ciiva.com/17423/rc0805-17423247.pdf?src-supplier=Future+Electronics; ComponentLink1URL: https://datasheet.ciiva.com/17423/rc0805-17423247.pdf?src-supplier=Future+Electronics; Depth: 1.25mm; Features: Moisture Resistant; Height: 0.5mm; Lead Free: Lead Free; Length: 2mm; Manufacturer Part Number: RC0805FR-071K5L; Max Operating Temperature: 155°C; Min Operating Temperature: -55°C; Number of Terminations: 2; Package Quantity: 5000; Packaging: Tape and Reel; Power Rating: 125mW; Pricing 1: 1=0.13000, 10=0.03100, 50=0.03100, 100=0.01100, 1000=0.00800, 10000=0.00500 (USD); REACH SVHC: Unknown; Resistance Tolerance: 1%; Resistor Type: Thick Film; RoHS: Yes; RoHS Compliant: Yes; Series: RC; Size Code: 0805; Stock 1: 4290; Supplier 1: Mouser; Supplier Part Number 1: 603-RC0805FR-071K5L; Termination: SMD/SMT; Tolerance: 1%; Width: 1.25mm; Library Name: Bitcoiner_EB_Project_V1I1.SchLib
R17 = RC0805FR-07200KL: YAGEO - RC0805FR-07200KL - RES, THICK FILM, 200K, 1%, 0.125W, 0805, REEL; Max Power Dissipation: 125mW; Resistance: 200kR; Temperature Coefficient: 100ppm/°C; Voltage Rating: 150V; Case Code (Imperial): 0805; Case Code (Metric): 2012; Case/Package: 0805; Category: Chip SMD Resistors; Component Kind: Standard; ComponentLink1Description: https://datasheet.ciiva.com/11808/0900766b8106beab-11808861.pdf?src-supplier=RSComponents; ComponentLink1URL: https://datasheet.ciiva.com/11808/0900766b8106beab-11808861.pdf?src-supplier=RSComponents; ComponentLink2Description: https://datasheet.ciiva.com/15189/getdatasheetpartid-71710-15189925.pdf?src-supplier=Verical; ComponentLink2URL: https://datasheet.ciiva.com/15189/getdatasheetpartid-71710-15189925.pdf?src-supplier=Verical; ComponentLink3Description: https://datasheet.ciiva.com/46395/444164-46395126.pdf?src-supplier=Element14; ComponentLink3URL: https://datasheet.ciiva.com/46395/444164-46395126.pdf?src-supplier=Element14; ComponentLink4Description: https://datasheet.ciiva.com/12668/rc0805-12668200.pdf?src-supplier=Mouser; ComponentLink4URL: https://datasheet.ciiva.com/12668/rc0805-12668200.pdf?src-supplier=Mouser; Construction: Rectangular; Contact Plating: Tin,Matte; Depth: 1.25mm; Features: Moisture Resistant; Height: 0.5mm; Lead Free: Lead Free; Length: 2mm; Manufacturer: Yageo; Manufacturer Part Number: RC0805FR-07200KL; Max Operating Temperature: 155°C; Military Standard: Not; Min Operating Temperature: -55°C; Number of Pins: 2; Number of Terminations: 2; Packaging: Tape and Reel; Power Rating: 125mW; Pricing 1: 1=0.10000, 10=0.03100, 50=0.03100, 100=0.01200, 1000=0.00700, 10000=0.00400 (USD); Radiation Hardening: No; REACH SVHC: No SVHC; Resistance Tolerance: 1%; Resistor Type: Thick Film; RoHS: Yes; RoHS Compliant: Yes; Series: RC; Stock 1: 217683; Supplier 1: Mouser; Supplier Part Number 1: 603-RC0805FR-07200KL; Technology: THICK FILM; Termination: SMD/SMT; Tolerance: 1%; Voltage Rating (DC): 150V; Working Voltage: 150V; Library Name: Bitcoiner_EB_Project_V1I1.SchLib
R21 = RE0805FRE0727KL: Chip Resistor Precision Grade Thick Film 0805 27kOhm 1% Paper T/R; Manufacturer: Yageo; Max Power Dissipation: 125mW; Resistance: 27kR; Temperature Coefficient: 50ppm/°C; Case/Package: 0805; Category: Chip SMD Resistors; Component Kind: Standard; ComponentLink1Description: http://www.yageo.com/NewPortal/yageodocoutput?fileName=/pdf/R-Chip/PYu-RE_105_RoHS_L_4.pdf&src-supplier=Digi-Key; ComponentLink1URL: http://www.yageo.com/NewPortal/yageodocoutput?fileName=/pdf/R-Chip/PYu-RE_105_RoHS_L_4.pdf&src-supplier=Digi-Key; Features: Moisture Resistant; Height: 0.024inch; Length: 0.079inch; Manufacturer Part Number: RE0805FRE0727KL; Max Operating Temperature: 155°C; Min Operating Temperature: -55°C; Number of Terminations: 2; Packaging: Tape and Reel; Pricing 1: 1=0.14000, 10=0.03300, 50=0.03300, 100=0.01200, 1000=0.00900, 10000=0.00500 (USD); Resistor Type: Thick Film; RoHS: Yes; RoHS Compliant: Yes; Stock 1: 11410; Supplier 1: Mouser; Supplier Part Number 1: 603-RE0805FRE0727KL; Tolerance: 1%; Width: 0.049inch; Library Name: Bitcoiner_EB_Project_V1I1.SchLib
R23-R30 = ERJ-P06F49R9V: Thick Film Resistors - SMD 0805 49.9ohms 0.5W 1% AEC-Q200; Manufacturer: Panasonic; Power Rating: 500mW; Temperature Coefficient: 100ppm/°C; Voltage Rating: 150V; Voltage Rating (DC): 400V; Case Code (Imperial): 0805; Case Code (Metric): 2012; Case/Package: 0805; Category: Chip SMD Resistors; Component Kind: Standard; ComponentLink1Description: https://datasheet.ciiva.com/22743/1801181-22743719.pdf?src-supplier=Farnell; ComponentLink1URL: https://datasheet.ciiva.com/22743/1801181-22743719.pdf?src-supplier=Farnell; ComponentLink2Description: https://datasheet.ciiva.com/46382/1801181-46382889.pdf?src-supplier=Element14; ComponentLink2URL: https://datasheet.ciiva.com/46382/1801181-46382889.pdf?src-supplier=Element14; ComponentLink3Description: https://datasheet.ciiva.com/24036/aoa0000ce4-64075-24036238.pdf?src-supplier=Mouser; ComponentLink3URL: https://datasheet.ciiva.com/24036/aoa0000ce4-64075-24036238.pdf?src-supplier=Mouser; ComponentLink4Description: https://datasheet.ciiva.com/22672/1801181-22672941.pdf?src-supplier=Element14; ComponentLink4URL: https://datasheet.ciiva.com/22672/1801181-22672941.pdf?src-supplier=Element14; Depth: 1.25mm; Height: 0.6mm; Length: 2mm; Manufacturer Part Number: ERJ-P06F49R9V; Max Operating Temperature: 155°C; Min Operating Temperature: -55°C; Mount: Surface Mount; Package Quantity: 5000; Packaging: Tape and Reel; Pricing 1: 1=0.15000, 10=0.08600, 50=0.07100, 100=0.04800, 1000=0.02200, 10000=0.02100 (USD); REACH SVHC: No SVHC; Resistance: 49.9R; Resistor Type: Thick Film; RoHS: Yes; RoHS Compliant: Yes; Stock 1: 6021; Supplier 1: Mouser; Supplier Part Number 1: 667-ERJ-P06F49R9V; Termination: Flat; Tolerance: 1%; Width: 1.2mm; Library Name: Bitcoiner_EB_Project_V1I1.SchLib
R37 = RC0805FR-07100KL: YAGEO         RC0805FR-07100KL            RES, THICK FILM, 100K, 1%, 0.125W, 0805, REEL; Max Power Dissipation: 125mW; Resistance: 100kR; Temperature Coefficient: 100ppm/°C; Voltage Rating: 150V; Case Code (Imperial): 0805; Case Code (Metric): 2012; Case/Package: 0805; Category: Chip SMD Resistors; Component Kind: Standard; ComponentLink1Description: https://datasheet.ciiva.com/11808/0900766b8106beab-11808858.pdf?src-supplier=RSComponents; ComponentLink1URL: https://datasheet.ciiva.com/11808/0900766b8106beab-11808858.pdf?src-supplier=RSComponents; ComponentLink2Description: https://datasheet.ciiva.com/2457/pyu-r-int-thick-7-2457017.pdf?src-supplier=Digi-Key; ComponentLink2URL: https://datasheet.ciiva.com/2457/pyu-r-int-thick-7-2457017.pdf?src-supplier=Digi-Key; ComponentLink3Description: https://datasheet.ciiva.com/12667/rc0805-12667001.pdf?src-supplier=Mouser; ComponentLink3URL: https://datasheet.ciiva.com/12667/rc0805-12667001.pdf?src-supplier=Mouser; ComponentLink4Description: https://datasheet.ciiva.com/2457/pyu-r-int-thick-7-2457018.pdf?src-supplier=Digi-Key; ComponentLink4URL: https://datasheet.ciiva.com/2457/pyu-r-int-thick-7-2457018.pdf?src-supplier=Digi-Key; ComponentLink5Description: https://datasheet.ciiva.com/16747/rc0805-16747226.pdf?src-supplier=Future+Electronics; ComponentLink5URL: https://datasheet.ciiva.com/16747/rc0805-16747226.pdf?src-supplier=Future+Electronics; ComponentLink6Description: https://datasheet.ciiva.com/2457/pyu-r-int-thick-7-2457019.pdf?src-supplier=Digi-Key; ComponentLink6URL: https://datasheet.ciiva.com/2457/pyu-r-int-thick-7-2457019.pdf?src-supplier=Digi-Key; ComponentLink7Description: https://datasheet.ciiva.com/7660/38627-7660796.pdf?src-supplier=Element14; ComponentLink7URL: https://datasheet.ciiva.com/7660/38627-7660796.pdf?src-supplier=Element14; ComponentLink8Description: https://datasheet.ciiva.com/7941/1697719-7941676.pdf?src-supplier=Element14; ComponentLink8URL: https://datasheet.ciiva.com/7941/1697719-7941676.pdf?src-supplier=Element14; ComponentLink9Description: https://datasheet.ciiva.com/7941/1686128-7941677.pdf?src-supplier=Element14; ComponentLink9URL: https://datasheet.ciiva.com/7941/1686128-7941677.pdf?src-supplier=Element14; ComponentLink10Description: https://datasheet.ciiva.com/7941/1697719-7941678.pdf?src-supplier=Element14; ComponentLink10URL: https://datasheet.ciiva.com/7941/1697719-7941678.pdf?src-supplier=Element14; ComponentLink11Description: https://datasheet.ciiva.com/22747/444164-22747534.pdf?src-supplier=Element14; ComponentLink11URL: https://datasheet.ciiva.com/22747/444164-22747534.pdf?src-supplier=Element14; Construction: Rectangular; Depth: 1.25mm; Features: Moisture Resistant; Height: 0.024inch; Lead Free: Lead Free; Lead Pitch: 0.4mm; Length: 2mm; Manufacturer: Yageo; Manufacturer Part Number: RC0805FR-07100KL; Max Operating Temperature: 155°C; Military Standard: Not; Min Operating Temperature: -55°C; Number of Pins: 2; Number of Terminations: 2; Package Quantity: 1; Packaging: Tape and Reel; Power Rating: 125mW; Pricing 1: 1=0.13000, 10=0.03100, 50=0.03100, 100=0.01100, 1000=0.00800, 10000=0.00400 (USD); REACH SVHC: Unknown; Resistance Tolerance: 1%; Resistor Type: Thick Film; RoHS: Yes; RoHS Compliant: Yes; Series: RC; Size Code: 0805; Stock 1: 419806; Supplier 1: Mouser; Supplier Part Number 1: 603-RC0805FR-07100KL; Technology: THICK FILM; Termination: SMD/SMT; Tolerance: 1%; Voltage Rating (DC): 150V; Width: 0.049inch; Library Name: Bitcoiner_EB_Project_V1I1.SchLib
R38 = RC0805FR-071ML: Res Thick Film 0805 1M Ohm 1% 0.125W(1/8W) ±100ppm/C Epoxy SMD T/R; Manufacturer: Yageo Phycomp; Power Rating: 125mW; Resistance: 1MR; Temperature Coefficient: 100ppm/°C; Voltage Rating (DC): 150V; Case/Package: 0805; Category: Chip SMD Resistors; Component Kind: Standard; Depth: 1.25mm; Height: 0.5mm; Lead Free: Lead Free; Length: 2mm; Manufacturer Part Number: RC0805FR-071ML; Max Operating Temperature: 155°C; Min Operating Temperature: -55°C; Mount: Surface Mount; Number of Pins: 2; Packaging: Cut Tape; Pricing 1: 1=0.10000, 10=0.04000, 50=0.04000, 100=0.01600, 1000=0.00700, 10000=0.00400 (USD); REACH SVHC: No SVHC; RoHS: Yes; Stock 1: 51730; Supplier 1: Mouser; Supplier Part Number 1: 603-RC0805FR-071ML; Tolerance: 1%; Width: 1.25mm; Library Name: Bitcoiner_EB_Project_V1I1.SchLib
R39 R40 = RC0805FR-072K2L: RESISTOR, THICK FILM, 2.2KOHM, 125mW, 1%, FULL REEL; Manufacturer: Yageo; Resistance: 2.2kR; Temperature Coefficient: 100ppm/°C; Voltage Rating: 150V; Case Code (Imperial): 0805; Case Code (Metric): 2012; Case/Package: 0805; Category: Chip SMD Resistors; Component Kind: Standard; ComponentLink1Description: https://datasheet.ciiva.com/7660/38627-7660800.pdf?src-supplier=Element14; ComponentLink1URL: https://datasheet.ciiva.com/7660/38627-7660800.pdf?src-supplier=Element14; ComponentLink2Description: https://datasheet.ciiva.com/11808/0900766b8106beab-11808373.pdf?src-supplier=RSComponents; ComponentLink2URL: https://datasheet.ciiva.com/11808/0900766b8106beab-11808373.pdf?src-supplier=RSComponents; ComponentLink3Description: https://datasheet.ciiva.com/46447/444164-46447575.pdf?src-supplier=Element14; ComponentLink3URL: https://datasheet.ciiva.com/46447/444164-46447575.pdf?src-supplier=Element14; ComponentLink4Description: https://datasheet.ciiva.com/2457/pyu-r-int-thick-7-2457002.pdf?src-supplier=Digi-Key; ComponentLink4URL: https://datasheet.ciiva.com/2457/pyu-r-int-thick-7-2457002.pdf?src-supplier=Digi-Key; ComponentLink5Description: https://datasheet.ciiva.com/7941/444164-7941754.pdf?src-supplier=Element14; ComponentLink5URL: https://datasheet.ciiva.com/7941/444164-7941754.pdf?src-supplier=Element14; ComponentLink6Description: https://datasheet.ciiva.com/2457/pyu-r-int-thick-7-2457003.pdf?src-supplier=Digi-Key; ComponentLink6URL: https://datasheet.ciiva.com/2457/pyu-r-int-thick-7-2457003.pdf?src-supplier=Digi-Key; ComponentLink7Description: https://datasheet.ciiva.com/7941/444164-7941755.pdf?src-supplier=Element14; ComponentLink7URL: https://datasheet.ciiva.com/7941/444164-7941755.pdf?src-supplier=Element14; ComponentLink8Description: https://datasheet.ciiva.com/2457/pyu-r-int-thick-7-2457004.pdf?src-supplier=Digi-Key; ComponentLink8URL: https://datasheet.ciiva.com/2457/pyu-r-int-thick-7-2457004.pdf?src-supplier=Digi-Key; ComponentLink9Description: https://datasheet.ciiva.com/46412/444164-46412862.pdf?src-supplier=Element14; ComponentLink9URL: https://datasheet.ciiva.com/46412/444164-46412862.pdf?src-supplier=Element14; Construction: Rectangular; Depth: 1.25mm; Features: Moisture Resistant; Height: 0.5mm; Lead Free: Lead Free; Lead Pitch: 0.4mm; Length: 2mm; Manufacturer Part Number: RC0805FR-072K2L; Max Operating Temperature: 155°C; Max Power Dissipation: 125mW; Military Standard: Not; Min Operating Temperature: -55°C; Number of Pins: 2; Number of Terminations: 2; Package Quantity: 1; Packaging: Tape and Reel; Power Rating: 125mW; Pricing 1: 1=0.10000, 10=0.03100, 50=0.03100, 100=0.01200, 1000=0.00700, 10000=0.00400 (USD); REACH SVHC: No SVHC; Resistance Tolerance: 1%; Resistor Type: Thick Film; RoHS: Yes; RoHS Compliant: Yes; Series: RC; Size Code: 0805; Stock 1: 637432; Supplier 1: Mouser; Supplier Part Number 1: 603-RC0805FR-072K2L; Technology: THICK FILM; Termination: SMD/SMT; Tolerance: 1%; Voltage Rating (DC): 150V; Width: 1.25mm; Library Name: Bitcoiner_EB_Project_V1I1.SchLib
R42 = CR0805-FX-5232ELF: Res Thick Film 0805 52.3K Ohm 1% 1/8W ±100ppm/°C Molded SMD SMD Paper Tape on Plastic Reel; Manufacturer: Bourns; Max Power Dissipation: 125mW; Resistance: 52.3kR; Voltage Rating: 150V; Case Code (Imperial): 0805; Case Code (Metric): 2012; Case/Package: 0805; Category: Chip SMD Resistors; Component Kind: Standard; ComponentLink1Description: https://datasheet.ciiva.com/16926/chpreztr-16926583.pdf?src-supplier=Avnet+Europe; ComponentLink1URL: https://datasheet.ciiva.com/16926/chpreztr-16926583.pdf?src-supplier=Avnet+Europe; ComponentLink2Description: https://datasheet.ciiva.com/9121/chpreztr-9121194.pdf?src-supplier=Avnet; ComponentLink2URL: https://datasheet.ciiva.com/9121/chpreztr-9121194.pdf?src-supplier=Avnet; ComponentLink3Description: https://datasheet.ciiva.com/5574/chpreztr-5574347.pdf?src-supplier=Mouser; ComponentLink3URL: https://datasheet.ciiva.com/5574/chpreztr-5574347.pdf?src-supplier=Mouser; Construction: Rectangular; Contact Plating: Tin; Depth: 1.25mm; Features: Moisture Resistant; Height: 0.5mm; Length: 2mm; Manufacturer Part Number: CR0805-FX-5232ELF; Max Operating Temperature: 155°C; Military Standard: Not; Min Operating Temperature: -55°C; Mount: Surface Mount; Number of Terminations: 2; Package Quantity: 5000; Packaging: Tape and Reel; Power Rating: 125mW; Pricing 1: 1=0.10000, 10=0.02400, 50=0.02400, 100=0.01400, 1000=0.00800, 10000=0.00400 (USD); Radiation Hardening: No; Resistor Type: Thick Film; RoHS: Yes; RoHS Compliant: Yes; Series: CR0805; Stock 1: 18366; Supplier 1: Mouser; Supplier Part Number 1: 652-CR0805FX-5232ELF; Temperature Coefficient: 100ppm/°C; Termination: SMD/SMT; Thickness: 508um; Tolerance: 1%; Width: 1.25mm; Library Name: Bitcoiner_EB_Project_V1I1.SchLib
R44 R45 = RC0805FR-070RL: Res Thick Film 0805 0 Ohm Epoxy Pad SMD T/R; Manufacturer: Yageo; Max Power Dissipation: 125mW; Resistance: 0mR; Tolerance: 1%; Case Code (Imperial): 0805; Case Code (Metric): 2012; Case/Package: 0805; Category: Chip SMD Resistors; Component Kind: Standard; ComponentLink1Description: https://datasheet.ciiva.com/17042/rc0805-17042698.pdf?src-supplier=Future+Electronics; ComponentLink1URL: https://datasheet.ciiva.com/17042/rc0805-17042698.pdf?src-supplier=Future+Electronics; ComponentLink2Description: https://datasheet.ciiva.com/15189/getdatasheetpartid-348154-15189885.pdf?src-supplier=Verical; ComponentLink2URL: https://datasheet.ciiva.com/15189/getdatasheetpartid-348154-15189885.pdf?src-supplier=Verical; Construction: Rectangular; Contact Plating: Tin,Matte; Depth: 1.25mm; Features: Moisture Resistant; Lead Free: Lead Free; Length: 2mm; Manufacturer Part Number: RC0805FR-070RL; Max Operating Temperature: 155°C; Military Standard: Not; Min Operating Temperature: -55°C; Number of Terminations: 2; Packaging: Tape and Reel; Power Rating: 125mW; Pricing 1: 1=0.10000, 10=0.03400, 50=0.03400, 100=0.01300, 1000=0.00700, 10000=0.00400 (USD); Radiation Hardening: No; Resistor Type: Thick Film; RoHS: Yes; RoHS Compliant: Yes; Series: RC; Size Code: 0805; Stock 1: 32082; Supplier 1: Mouser; Supplier Part Number 1: 603-RC0805FR-070RL; Library Name: Bitcoiner_EB_Project_V1I1.SchLib
R46 = 0ZCM0012FF2G: PTC RESET FUSE 9V 120MA 0603; Manufacturer: Bel; Max Current Rating: 40A; Time to Trip: 0.1s; Trip Current: 300mA; Case Code (Imperial): 0603; Case Code (Metric): 1608; Case/Package: 0603; Category: PTC Resettable Fuses; Component Kind: Standard; ComponentLink1Description: https://datasheet.ciiva.com/18550/0zcm-18550763.pdf?src-supplier=Digi-Key; ComponentLink1URL: https://datasheet.ciiva.com/18550/0zcm-18550763.pdf?src-supplier=Digi-Key; ComponentLink2Description: https://datasheet.ciiva.com/18550/0zcm-18550764.pdf?src-supplier=Digi-Key; ComponentLink2URL: https://datasheet.ciiva.com/18550/0zcm-18550764.pdf?src-supplier=Digi-Key; ComponentLink3Description: https://datasheet.ciiva.com/18550/0zcm-18550765.pdf?src-supplier=Digi-Key; ComponentLink3URL: https://datasheet.ciiva.com/18550/0zcm-18550765.pdf?src-supplier=Digi-Key; Hold Current: 120mA; Manufacturer Part Number: 0ZCM0012FF2G; Package Quantity: 1; Packaging: Tape and Reel; Pricing 1: 1=0.13000, 10=0.11800, 50=0.11800, 100=0.08400, 1000=0.06100, 10000=0.05000 (USD); RoHS: Yes; RoHS Compliant: Yes; Stock 1: 3742; Supplier 1: Mouser; Supplier Part Number 1: 530-0ZCM0012FF2G; Library Name: Bitcoiner_EB_Project_V1I1.SchLib
